# Altium schematic sheet 03-10715_Rev_0.1_Sheet_2.SchDoc (continued)

## Library data of the parts
C16 C17 C20 C22 C25 C32 = CAP0603: Capacitor; Manufacturer 1: AVX; Manufacturer Part Number 1: 06033A471JAT2A; Description_: CAP CER 470pF 25V 5% NP0 SMD 0603; Populated: Yes; Temperature Coeffcient: NP0; Tolerance: 5%; Type: Ceramic; Voltage: 25V; Category: Capacitor; Component Kind: Standard; ComponentLink1Description: Datasheet; ComponentLink1URL: https://chn-vm-apps.microchip.com/mchprepo/parts/Datasheets/smccp.pdf; Database Table Name: Capacitor; Library Name: MCHP-CDB.DbLib; MCHP-ID: CAP0603; Operating Temperature: -55C to +125C; Package: 0603; Quantity Override: 1; Revision: 1; Status: Production; Supplier 1: Digi-Key; Supplier Part Number 1: 478-6201-1-ND
C18 C19 C26 C28 = CAP0594: Capacitor; Manufacturer 1: AVX; Manufacturer Part Number 1: 06035A102JAT2A; Description_: CAP CER 1000pF 50V 5% C0G SMD 0603; Populated: Yes; Temperature Coeffcient: C0G; Tolerance: 5%; Type: Ceramic; Voltage: 50V; Category: Capacitor; Component Kind: Standard; ComponentLink1Description: Datasheet; ComponentLink1URL: https://chn-vm-apps.microchip.com/mchprepo/parts/Datasheets/smccp.pdf; Database Table Name: Capacitor; Library Name: MCHP-CDB.DbLib; Manufacturer 2: TDK; Manufacturer Part Number 2: C1608C0G1H102J; MCHP-ID: CAP0594; Operating Temperature: -55C to +125C; Package: 0603; Quantity Override: 1; Revision: 1; Status: Production; Supplier 1: Digi-Key; Supplier 2: Digi-Key; Supplier Part Number 1: 478-1187-2-ND; Supplier Part Number 2: 445-1293-2-ND
C21 = CAP1130: Capacitor; Manufacturer 1: TDK; Manufacturer Part Number 1: C1608X7R1H333K; Description_: CAP CER 0.033uF 50V 10% X7R SMD 0603; Dev Tools Status: Production; Owner: Bogdan P (M91398); Populated: YES; Temperature Coeffcient: X7R; Tolerance: 10%; Type: Ceramic; Voltage: 50V; Category: Capacitor; Component Kind: Standard; ComponentLink1Description: Datasheet; ComponentLink1URL: https://chn-vm-apps.microchip.com/mchprepo/parts/Datasheets/general_B11.pdf; Database Table Name: Capacitor; Library Name: MCHP-CDB.DbLib; MCHP-ID: CAP1130; Operating Temperature: -55C to +125C; Package: 0603; Quantity Override: 1; Revision: 0; Status: MCL Design; Supplier 1: Digi-Key; Supplier Part Number 1: 445-5093-1-ND; Symbol: Capacitor NP
C23 C27 = CAP0011: Capacitor; Manufacturer 1: NIC Components; Manufacturer Part Number 1: NMC0603X7R104K16TRPF; Description_: CAP CER 0.1uF 16V 10% X7R SMD 0603; Populated: Yes; Temperature Coeffcient: X7R; Tolerance: 10%; Type: Ceramic; Category: Capacitor; Component Kind: Standard; ComponentLink1Description: Datasheet; ComponentLink1URL: https://chn-vm-apps.microchip.com/mchprepo/parts/Datasheets/nmc2.pdf; Database Table Name: Capacitor; Library Name: MCHP-CDB.DbLib; Manufacturer 2: Panasonic; Manufacturer 3: Taiyo Yuden; Manufacturer 4: Yageo; Manufacturer 5: Murata; Manufacturer 6: AVX; Manufacturer Part Number 2: ECJ-1VB1C104K; Manufacturer Part Number 3: EMK107B7104KA-T; Manufacturer Part Number 4: CC0603KRX7R7BB104; Manufacturer Part Number 5: GRM188R71C104KA01D; Manufacturer Part Number 6: 0603YC104KAT2A; MCHP-ID: CAP0011; Operating Temperature: -55C to +125C; Quantity Override: 1; Revision: 3; Status: Production; Supplier 1: NIC Components; Supplier 2: Digi-Key; Supplier 3: Digi-Key; Supplier 4: Newark; Supplier 5: Digi-Key; Supplier 6: Digi-Key; Supplier 7: Digi-Key; Supplier Part Number 1: NMC0603X7R104K16TRPF; Supplier Part Number 2: PCC1762CT-ND; Supplier Part Number 3: 587-1240-2-ND; Supplier Part Number 4: 86H5248; Supplier Part Number 5: 311-1088-1-ND; Supplier Part Number 6: 490-1532-1-ND; Supplier Part Number 7: 478-1239-1-ND
  C27: Package: 0603
C24 C30 C34 = CAP0011: Capacitor; Manufacturer 1: NIC Components; Manufacturer Part Number 1: NMC0603X7R104K16TRPF; Description_: CAP CER 0.1uF 16V 10% X7R SMD 0603; Temperature Coeffcient: X7R; Tolerance: 10%; Type: Ceramic; Value: 0.1uF; Voltage: 16V; Category: Capacitor; Component Kind: Standard; ComponentLink1Description: Datasheet; ComponentLink1URL: https://chn-vm-apps.microchip.com/mchprepo/parts/Datasheets/nmc2.pdf; Database Table Name: Capacitor; Library Name: MCHP-CDB.DbLib; Manufacturer 2: Panasonic; Manufacturer 3: Taiyo Yuden; Manufacturer 4: Yageo; Manufacturer 5: AVX; Manufacturer Part Number 2: ECJ-1VB1C104K; Manufacturer Part Number 3: EMK107B7104KA-T; Manufacturer Part Number 4: CC0603KRX7R7BB104; Manufacturer Part Number 5: 0603YC104KAT2A; MCHP-ID: CAP0011; Operating Temperature: -55C to +125C; Quantity Override: 1; Revision: 3; Status: Production; Supplier 1: NIC Components; Supplier 2: Digi-Key; Supplier 3: Digi-Key; Supplier 4: Newark; Supplier 5: Digi-Key; Supplier 6: Digi-Key; Supplier Part Number 1: NMC0603X7R104K16TRPF; Supplier Part Number 2: PCC1762CT-ND; Supplier Part Number 3: 587-1240-2-ND; Supplier Part Number 4: 86H5248; Supplier Part Number 5: 311-1088-1-ND; Supplier Part Number 6: 478-1239-1-ND
  C34: Package: 0603
C29 = CAP0594: Capacitor; Manufacturer 1: AVX; Manufacturer Part Number 1: 06035A102JAT2A; Description_: CAP CER 1000pF 50V 5% C0G SMD 0603; Temperature Coeffcient: C0G; Tolerance: 5%; Type: Ceramic; Value: 1000pF; Voltage: 50V; Category: Capacitor; Component Kind: Standard; ComponentLink1Description: Datasheet; ComponentLink1URL: https://chn-vm-apps.microchip.com/mchprepo/parts/Datasheets/smccp.pdf; Database Table Name: Capacitor; Library Name: MCHP-CDB.DbLib; Manufacturer 2: TDK; Manufacturer Part Number 2: C1608C0G1H102J; MCHP-ID: CAP0594; Operating Temperature: -55C to +125C; Package: 0603; Quantity Override: 1; Revision: 1; Status: Production; Supplier 1: Digi-Key; Supplier 2: Digi-Key; Supplier Part Number 1: 478-1187-2-ND; Supplier Part Number 2: 445-1293-2-ND
C31 C33 = CAP0011: Capacitor; Manufacturer 1: NIC Components; Manufacturer Part Number 1: NMC0603X7R104K16TRPF; Description_: CAP CER 0.1uF 16V 10% X7R SMD 0603; Populated: Yes; Temperature Coeffcient: X7R; Tolerance: 10%; Type: Ceramic; Voltage: 16V; Category: Capacitor; Component Kind: Standard; ComponentLink1Description: Datasheet; ComponentLink1URL: https://chn-vm-apps.microchip.com/mchprepo/parts/Datasheets/nmc2.pdf; Database Table Name: Capacitor; Library Name: MCHP-CDB.DbLib; Manufacturer 2: Panasonic; Manufacturer 3: Taiyo Yuden; Manufacturer 4: Yageo; Manufacturer 5: Murata; Manufacturer 6: AVX; Manufacturer Part Number 2: ECJ-1VB1C104K; Manufacturer Part Number 3: EMK107B7104KA-T; Manufacturer Part Number 4: CC0603KRX7R7BB104; Manufacturer Part Number 5: GRM188R71C104KA01D; Manufacturer Part Number 6: 0603YC104KAT2A; MCHP-ID: CAP0011; Operating Temperature: -55C to +125C; Package: 0603; Quantity Override: 1; Revision: 3; Status: Production; Supplier 1: NIC Components; Supplier 2: Digi-Key; Supplier 3: Digi-Key; Supplier 4: Newark; Supplier 5: Digi-Key; Supplier 6: Digi-Key; Supplier 7: Digi-Key; Supplier Part Number 1: NMC0603X7R104K16TRPF; Supplier Part Number 2: PCC1762CT-ND; Supplier Part Number 3: 587-1240-2-ND; Supplier Part Number 4: 86H5248; Supplier Part Number 5: 311-1088-1-ND; Supplier Part Number 6: 490-1532-1-ND; Supplier Part Number 7: 478-1239-1-ND
C35-C38 = CAP0035: Capacitor; Manufacturer 1: Panasonic; Manufacturer Part Number 1: ECU-V1H101JCV; Description_: CAP CER 100pF 50V 5% NP0 SMD 0603; Populated: Yes; Temperature Coeffcient: NP0; Tolerance: 5%; Type: Ceramic; Voltage: 50V; Category: Capacitor; Component Kind: Standard; ComponentLink1Description: Datasheet; ComponentLink1URL: https://chn-vm-apps.microchip.com/mchprepo/parts/Datasheets/ECJ__General_.pdf; Database Table Name: Capacitor; Library Name: MCHP-CDB.DbLib; Manufacturer 2: Cal-Chip; Manufacturer 3: NIC Components; Manufacturer 4: Panasonic; Manufacturer 5: AVX; Manufacturer 6: TDK; Manufacturer Part Number 2: GMC10CG101J50NTLF; Manufacturer Part Number 3: NMC0603NPO101J50TRPF; Manufacturer Part Number 4: ECJ-1VC1H101J; Manufacturer Part Number 5: 06035A101JAT2A; Manufacturer Part Number 6: C1608C0G1H101J/10; MCHP-ID: CAP0035; Operating Temperature: -55C to +125C; Package: 0603; Quantity Override: 1; Revision: 2; Status: Production; Supplier 1: Digi-Key; Supplier 2: Cal-Chip; Supplier 3: NIC Components; Supplier 4: Digi-Key; Supplier 5: Digi-Key; Supplier 6: Digi-Key; Supplier Part Number 1: PCC101ACVTR-ND; Supplier Part Number 2: GMC10CG101J50NTLF; Supplier Part Number 3: NMC0603NPO101J50TRPF; Supplier Part Number 4: PCC101ACVCT-ND; Supplier Part Number 5: 478-1175-1-ND; Supplier Part Number 6: C1608C0G1H101J/10-ND
C75 = CAP0035: Capacitor; Manufacturer 1: Cal-Chip; Manufacturer Part Number 1: GMC10CG101J50NTLF; Description_: CAP CER 100pF 50V 5% NP0 SMD 0603; Dev Tools Status: Production; Populated: DNP; Temperature Coeffcient: NP0; Tolerance: 5%; Type: Ceramic; Voltage: 50V; Category: Capacitor; Component Kind: Standard; ComponentLink1Description: SVN Datasheet; ComponentLink1URL: https://chn-vm-apps.microchip.com/mchprepo/parts/Datasheets/ECJ__General_.pdf; ComponentLink2Description: Local Datasheet; ComponentLink3Description: Open Symbol; ComponentLink4Description: Open Footprint; ComponentLink5Description: Open Footprint 2; ComponentLink6Description: Open Footprint 3; ComponentLink7Description: Open Footprint 4; Database Table Name: Capacitor; Library Name: MCHP-CDB-Offline.DbLib; Manufacturer 2: AVX; Manufacturer 3: Panasonic; Manufacturer 4: Panasonic; Manufacturer 5: TDK; Manufacturer 6: NIC Components; Manufacturer Part Number 2: 06035A101JAT2A; Manufacturer Part Number 3: ECU-V1H101JCV; Manufacturer Part Number 4: ECJ-1VC1H101J; Manufacturer Part Number 5: C1608C0G1H101J/10; Manufacturer Part Number 6: NMC0603NPO101J50TRPF; MCHP-ID: CAP0035; Operating Temperature: -55C to +125C; Package: 0603; Quantity Override: 1; Revision: 2; Status: MCL Design; Supplier 1: Cal-Chip; Supplier 2: Digi-Key; Supplier 3: Digi-Key; Supplier 4: Digi-Key; Supplier 5: Digi-Key; Supplier 6: NIC Components; Supplier Part Number 1: GMC10CG101J50NTLF; Supplier Part Number 2: 478-1175-1-ND; Supplier Part Number 3: PCC101ACVTR-ND; Supplier Part Number 4: PCC101ACVCT-ND; Supplier Part Number 5: C1608C0G1H101J/10-ND; Supplier Part Number 6: NMC0603NPO101J50TRPF; Symbol: Capacitor NP; ComponentLink2URL: C:\ALTIUM_WORK\00001_MCHP-CDB\Datasheets\ECJ__General_.pdf; ComponentLink3URL: C:\ALTIUM_WORK\00001_MCHP-CDB\Library\LibraryRefs\Capacitor NP.SchLib; ComponentLink4URL: C:\ALTIUM_WORK\00001_MCHP-CDB\Library\Footprints\CAP_C_0603.PcbLib
C78-C80 = CAP1635: Capacitor; Manufacturer 1: TDK Corporation; Manufacturer Part Number 1: C1608C0G1H121J080AA; Description_: CAP CER 120pF 50V 5% C0G SMD 0603; Dev Tools Status: Design; Owner: Razvan Cristescu; Populated: YES; Temperature Coeffcient: C0G; Tolerance: 5%; Type: Ceramic; Voltage: 50V; Category: Capacitor; Component Kind: Standard; ComponentLink1Description: SVN Datasheet; ComponentLink1URL: https://chn-vm-apps.microchip.com/mchprepo/parts/Datasheets/1913358.pdf; ComponentLink2Description: Local Datasheet; ComponentLink3Description: Open Symbol; ComponentLink4Description: Open Footprint; ComponentLink5Description: Open Footprint 2; ComponentLink6Description: Open Footprint 3; ComponentLink7Description: Open Footprint 4; Database Table Name: Capacitor; Library Name: MCHP-CDB.DbLib; MCHP-ID: CAP1635; Operating Temperature: -55C to +125C; Package: 0603; Quantity Override: 1; Revision: A; Status: MCL Design; Supplier 1: Farnell; Supplier Part Number 1: 2371946; Symbol: Capacitor NP; ComponentLink2URL: C:\ALTIUM_WORK\00001_MCHP-CDB\Datasheets\1913358.pdf; ComponentLink3URL: C:\ALTIUM_WORK\00001_MCHP-CDB\Library\LibraryRefs\Capacitor NP.SchLib; ComponentLink4URL: C:\ALTIUM_WORK\00001_MCHP-CDB\Library\Footprints\CAP_C_0603.PcbLib
D17-D19 = DIODE1074: Manufacturer 1: Vishay Semiconductor Diodes Division; Manufacturer Part Number 1: BAS40-04-E3-08; Description_: DIO SCTKYARR BAS40-04 1V 200mA 40V SOT-23-3; Dev Tools Status: Production; Forward Current: 200mA; Owner: Stephen Humberd; Populated: YES; Power: 200mW; Reverse Current: 100nA; Reverse Voltage: 40V; Type: Schottky Array; Category: Diode; Component Kind: Standard; ComponentLink1Description: SVN Datasheet; ComponentLink1URL: https://chn-vm-apps.microchip.com/mchprepo/parts/Datasheets/bas40v.pdf; ComponentLink2Description: Local Datasheet; ComponentLink3Description: Open Symbol; ComponentLink4Description: Open Footprint; ComponentLink5Description: Open Footprint 2; ComponentLink6Description: Open Footprint 3; ComponentLink7Description: Open Footprint 4; Database Table Name: Diode; Library Name: MCHP-CDB.DbLib; MCHP-ID: DIODE1074; Operating Temperature: -65C to +125C; Package: SOT-23-3; Quantity Override: 1; Revision: A; Status: MCL Design; Supplier 1: Digi-Key; Supplier Part Number 1: BAS40-04-E3-08CT-ND; Symbol: Diode Schottky DUAL AK; ComponentLink2URL: C:\ALTIUM_WORK\00001_MCHP-CDB\Datasheets\bas40v.pdf; ComponentLink3URL: C:\ALTIUM_WORK\00001_MCHP-CDB\Library\LibraryRefs\Diode Schottky DUAL AK.SchLib; ComponentLink4URL: C:\ALTIUM_WORK\00001_MCHP-CDB\Library\Footprints\SOT-23-3.PcbLib
DCBUS1 M1_IA M1_IB M1_IBUS QE_A QE_B QE_HOME QE_INDEX VA VB VC = CON1128: Test Point; Database Table Name: Connector; Description_: CON TP Via_1.2x0.7; Owner: Bogdan P (M91398); Populated: Yes; Value: 1x1; Category: Connector; ComponentLink1Description: Datasheet; Library Name: MCHP-CDB.DbLib; MCHP-ID: CON1128; Quantity Override: 1; Revision: 0; Status: Production; Type: Test Point
J3 = CON1187: Manufacturer 1: On Shore Technology Inc; Manufacturer Part Number 1: ED500/6DS; Current: 15A; Database Table Name: Connector; Description_: CON TERMINAL 5mm 1x6 Female 14-22AWG 15A TH R/A; Dev Tools Status: Production; Owner: Stephen Humberd; Voltage: 300V; Category: Connector; Component Kind: Standard; ComponentLink1Description: Datasheet; ComponentLink1URL: https://chn-vm-apps.microchip.com/mchprepo/parts/Datasheets/ed500.pdf; Library Name: MCHP-CDB.DbLib; MCHP-ID: CON1187; Quantity Override: 1; Revision: A; Status: MCL Design; Supplier 1: Digi-Key; Supplier Part Number 1: ED2223-ND; Symbol: Header 1x6; Type: Terminal Block
R46 R47 R58 R60 R67 R70 R85 R153-R157 = RES1318: Manufacturer 1: Stackpole Electronics Inc; Manufacturer Part Number 1: RMCF0603FT30K0; Circuit Type: Isolated; Description_: RES TKF 30k 1% 1/10W SMD 0603; Dev Tools Status: Production; Owner: Stephen Humberd; Populated: YES; Power: 1/10W; Temperature Coeffcient: 100ppm/C; Tolerance: 1%; Voltage: 75V; Category: Resistor; Component Kind: Standard; ComponentLink1Description: SVN Datasheet; ComponentLink1URL: https://chn-vm-apps.microchip.com/mchprepo/parts/Datasheets/AOA0000CE2.pdf; ComponentLink2Description: Local Datasheet; ComponentLink3Description: Open Symbol; ComponentLink4Description: Open Footprint; ComponentLink5Description: Open Footprint 2; ComponentLink6Description: Open Footprint 3; ComponentLink7Description: Open Footprint 4; Composition: TKF; Database Table Name: Resistor; Description: Resistor; Library Name: MCHP-CDB-Offline.DbLib; MCHP-ID: RES1318; Operating Temperature: -55C to +155C; Package: 0603; Quantity Override: 1; Revision: A; Status: MCL Design; Supplier 1: Digi-Key; Supplier Part Number 1: RMCF0603FT30K0TR-ND; Symbol: Resistor; Type: RES SMD; ComponentLink2URL: C:\ALTIUM_WORK\00001_MCHP-CDB\Datasheets\AOA0000CE2.pdf; ComponentLink3URL: C:\ALTIUM_WORK\00001_MCHP-CDB\Library\LibraryRefs\Resistor.SchLib; ComponentLink4URL: C:\ALTIUM_WORK\00001_MCHP-CDB\Library\Footprints\RES_C_0603.PcbLib
R48 R71 R82 = RSMT0138: Resistor; Manufacturer 1: Panasonic; Manufacturer Part Number 1: ERJ-3EKF1001V; Description_: RES TKF 1k 1% 1/10W SMD 0603; Power: 1/10W; Temperature Coeffcient: 100ppm/C; Tolerance: 1%; Value: 1k; Voltage: 75V; Category: Resistor; Component Kind: Standard; ComponentLink1Description: Datasheet; ComponentLink1URL: https://chn-vm-apps.microchip.com/mchprepo/parts/Datasheets/AOA0000CE2.pdf; Composition: TKF; Database Table Name: Resistor; Library Name: MCHP-CDB.DbLib; Manufacturer 2: ROHM; Manufacturer 3: Vishay; Manufacturer 4: Stackpole Electronics Inc; Manufacturer 5: Yageo; Manufacturer 6: Stackpole Electronics Inc; Manufacturer 7: Stackpole Electronics Inc; Manufacturer Part Number 2: MCR03EZPFX1001; Manufacturer Part Number 3: CRCW06031K00FKEA; Manufacturer Part Number 4: RMCF1/161.0K1%TR; Manufacturer Part Number 5: RC0603FR-071KL; Manufacturer Part Number 6: RMCF0603FT1K00; Manufacturer Part Number 7: RMCF 1/16 1K 1% R; MCHP-ID: RSMT0138; Operating Temperature: -55C to +155C; Package: 0603; Quantity Override: 1; Revision: 2; Status: Production; Supplier 1: Digi-Key; Supplier 2: Digi-Key; Supplier 3: Digi-Key; Supplier 4: Newark; Supplier 5: Stackpole Electronics Inc; Supplier 6: Digi-Key; Supplier 7: Digi-Key; Supplier 8: Digi-Key; Supplier Part Number 1: P1.00KHTR-ND; Supplier Part Number 2: P1.00KHCT-ND; Supplier Part Number 3: RHM1.00KHCT-ND; Supplier Part Number 4: 52K8015; Supplier Part Number 5: RMCF1/161.0K1%TR; Supplier Part Number 6: 311-1.00KHRCT-ND; Supplier Part Number 7: RMCF1/161KFRTR-ND; Supplier Part Number 8: RMCF0603FT1K00TR-ND; Type: RES SMD
R49-R52 R54-R57 R72 R73 R80 R81 R86 = RSMT0138: Resistor; Manufacturer 1: Panasonic; Manufacturer Part Number 1: ERJ-3EKF1001V; Description_: RES TKF 1k 1% 1/10W SMD 0603; Populated: Yes; Power: 1/10W; Temperature Coeffcient: 100ppm/C; Tolerance: 1%; Voltage: 75V; Category: Resistor; Component Kind: Standard; ComponentLink1Description: Datasheet; ComponentLink1URL: https://chn-vm-apps.microchip.com/mchprepo/parts/Datasheets/AOA0000CE2.pdf; Composition: TKF; Database Table Name: Resistor; Library Name: MCHP-CDB.DbLib; Manufacturer 2: ROHM; Manufacturer 3: Vishay; Manufacturer 4: Stackpole Electronics Inc; Manufacturer 5: Yageo; Manufacturer 6: Stackpole Electronics Inc; Manufacturer 7: Stackpole Electronics Inc; Manufacturer Part Number 2: MCR03EZPFX1001; Manufacturer Part Number 3: CRCW06031K00FKEA; Manufacturer Part Number 4: RMCF1/161.0K1%TR; Manufacturer Part Number 5: RC0603FR-071KL; Manufacturer Part Number 6: RMCF0603FT1K00; Manufacturer Part Number 7: RMCF 1/16 1K 1% R; MCHP-ID: RSMT0138; Operating Temperature: -55C to +155C; Package: 0603; Quantity Override: 1; Revision: 2; Status: Production; Supplier 1: Digi-Key; Supplier 2: Digi-Key; Supplier 3: Digi-Key; Supplier 4: Newark; Supplier 5: Stackpole Electronics Inc; Supplier 6: Digi-Key; Supplier 7: Digi-Key; Supplier 8: Digi-Key; Supplier Part Number 1: P1.00KHTR-ND; Supplier Part Number 2: P1.00KHCT-ND; Supplier Part Number 3: RHM1.00KHCT-ND; Supplier Part Number 4: 52K8015; Supplier Part Number 5: RMCF1/161.0K1%TR; Supplier Part Number 6: 311-1.00KHRCT-ND; Supplier Part Number 7: RMCF1/161KFRTR-ND; Supplier Part Number 8: RMCF0603FT1K00TR-ND; Type: RES SMD
R53 R66 R78 R89 = RES1126: Manufacturer 1: Panasonic; Manufacturer Part Number 1: ERJ-3EKF1802V; Description_: RES TKF 18k 1% 1/10W SMD 0603; Owner: Bogdan P (M91398); Populated: Yes; Power: 1/10W; Temperature Coeffcient: 100ppm/C; Tolerance: 1%; Voltage: 75V; Category: Resistor; Component Kind: Standard; ComponentLink1Description: Datasheet; ComponentLink1URL: https://chn-vm-apps.microchip.com/mchprepo/parts/Datasheets/AOA0000CE2.pdf; Composition: TKF; Database Table Name: Resistor; Description: Resistor; Library Name: MCHP-CDB.DbLib; MCHP-ID: RES1126; Operating Temperature: -55C to +155C; Quantity Override: 1; Revision: 0; Status: Production; Supplier 1: Digi-Key; Supplier Part Number 1: P18.0KHCT-ND; Type: RES SMD
  R66: Package: 0603
R59 R75 = RSMT0001: Resistor; Manufacturer 1: NIC Components; Manufacturer Part Number 1: NRC06Z0TRF; Description_: RES TKF 0R 1/10W SMD 0603; Populated: Yes; Power: 1/10W; Category: Resistor; Component Kind: Standard; ComponentLink1Description: Datasheet; ComponentLink1URL: https://chn-vm-apps.microchip.com/mchprepo/parts/Datasheets/nrc.pdf; Composition: TKF; Database Table Name: Resistor; Library Name: MCHP-CDB.DbLib; Manufacturer 2: Panasonic; Manufacturer 3: Panasonic; Manufacturer 4: Stackpole Electronics Inc; Manufacturer 5: Vishay; Manufacturer 6: Stackpole Electronics Inc; Manufacturer 7: Yageo; Manufacturer 8: KOA Speer; Manufacturer 9: ROHM; Manufacturer Part Number 2: ERJ-3GSY0R00V; Manufacturer Part Number 3: ERJ-3GEY0R00V; Manufacturer Part Number 4: RMCF0603ZT0R00; Manufacturer Part Number 5: CRCW06030000Z0EA; Manufacturer Part Number 6: RMCF 1/16 0 R; Manufacturer Part Number 7: RC0603JR-070RL; Manufacturer Part Number 8: RK73Z1JTTP; Manufacturer Part Number 9: MCR03EZPJ000; MCHP-ID: RSMT0001; Operating Temperature: -55C to +155C; Quantity Override: 1; Revision: 2; Status: Production; Supplier 1: NIC Components; Supplier 2: Digi-Key; Supplier 3: Digi-Key; Supplier 4: Digi-Key; Supplier 5: Digi-Key; Supplier 6: Digi-Key; Supplier 7: Digi-Key; Supplier 8: KOA Speer; Supplier 9: Digi-Key; Supplier Part Number 1: NRC06Z0TRF; Supplier Part Number 2: P0.0GTR-ND; Supplier Part Number 3: RMCF0603ZT0R00TR-ND; Supplier Part Number 4: RMCF0603ZT0R00CT-ND; Supplier Part Number 5: 541-0.0GCT-ND; Supplier Part Number 6: RMCF1/160RTR-ND; Supplier Part Number 7: 311-0.0GRCT-ND; Supplier Part Number 8: RK73Z1JTTP; Supplier Part Number 9: RHM0.0GCT-ND; Type: RES SMD
  R59: Package: 0603
R61 R68 R83 R91 = RES1110: Manufacturer 1: Panasonic; Manufacturer Part Number 1: ERJ-3EKF1801V; Description_: RES TKF 1.8k 1% 1/10W SMD 0603; Owner: Bogdan P (M91398); Populated: Yes; Power: 1/10W; Temperature Coeffcient: 100ppm/C; Tolerance: 1%; Voltage: 75V; Category: Resistor; Component Kind: Standard; ComponentLink1Description: Datasheet; ComponentLink1URL: https://chn-vm-apps.microchip.com/mchprepo/parts/Datasheets/AOA0000CE2.pdf; Composition: TKF; Database Table Name: Resistor; Description: Resistor; Library Name: MCHP-CDB.DbLib; MCHP-ID: RES1110; Operating Temperature: -55C to +155C; Quantity Override: 1; Revision: 0; Status: Production; Supplier 1: Digi-Key; Supplier Part Number 1: P1.80KHCT-ND; Type: RES SMD
R62 = RSMT0138: Resistor; Manufacturer 1: Panasonic; Manufacturer Part Number 1: ERJ-3EKF1001V; Description_: RES TKF 1k 1% 1/10W SMD 0603; Power: 1/10W; Temperature Coeffcient: 100ppm/C; Tolerance: 1%; Voltage: 75V; Category: Resistor; Component Kind: Standard; ComponentLink1Description: Datasheet; ComponentLink1URL: https://chn-vm-apps.microchip.com/mchprepo/parts/Datasheets/AOA0000CE2.pdf; Composition: TKF; Database Table Name: Resistor; Library Name: MCHP-CDB.DbLib; Manufacturer 2: ROHM; Manufacturer 3: Vishay; Manufacturer 4: Stackpole Electronics Inc; Manufacturer 5: Yageo; Manufacturer 6: Stackpole Electronics Inc; Manufacturer 7: Stackpole Electronics Inc; Manufacturer Part Number 2: MCR03EZPFX1001; Manufacturer Part Number 3: CRCW06031K00FKEA; Manufacturer Part Number 4: RMCF1/161.0K1%TR; Manufacturer Part Number 5: RC0603FR-071KL; Manufacturer Part Number 6: RMCF0603FT1K00; Manufacturer Part Number 7: RMCF 1/16 1K 1% R; MCHP-ID: RSMT0138; Operating Temperature: -55C to +155C; Package: 0603; Quantity Override: 1; Revision: 2; Status: Production; Supplier 1: Digi-Key; Supplier 2: Digi-Key; Supplier 3: Digi-Key; Supplier 4: Newark; Supplier 5: Stackpole Electronics Inc; Supplier 6: Digi-Key; Supplier 7: Digi-Key; Supplier 8: Digi-Key; Supplier Part Number 1: P1.00KHTR-ND; Supplier Part Number 2: P1.00KHCT-ND; Supplier Part Number 3: RHM1.00KHCT-ND; Supplier Part Number 4: 52K8015; Supplier Part Number 5: RMCF1/161.0K1%TR; Supplier Part Number 6: 311-1.00KHRCT-ND; Supplier Part Number 7: RMCF1/161KFRTR-ND; Supplier Part Number 8: RMCF0603FT1K00TR-ND; Type: RES SMD
R63 = RSMT0001: Resistor; Manufacturer 1: NIC Components; Manufacturer Part Number 1: NRC06Z0TRF; Description_: RES TKF 0R 1/10W SMD 0603; Power: 1/10W; Value: 0R; Category: Resistor; Component Kind: Standard; ComponentLink1Description: Datasheet; ComponentLink1URL: https://chn-vm-apps.microchip.com/mchprepo/parts/Datasheets/nrc.pdf; Composition: TKF; Database Table Name: Resistor; Library Name: MCHP-CDB.DbLib; Manufacturer 2: Panasonic; Manufacturer 3: Panasonic; Manufacturer 4: Stackpole Electronics Inc; Manufacturer 5: Vishay; Manufacturer 6: Stackpole Electronics Inc; Manufacturer 7: Yageo; Manufacturer 8: KOA Speer; Manufacturer 9: ROHM; Manufacturer Part Number 2: ERJ-3GSY0R00V; Manufacturer Part Number 3: ERJ-3GEY0R00V; Manufacturer Part Number 4: RMCF0603ZT0R00; Manufacturer Part Number 5: CRCW06030000Z0EA; Manufacturer Part Number 6: RMCF 1/16 0 R; Manufacturer Part Number 7: RC0603JR-070RL; Manufacturer Part Number 8: RK73Z1JTTP; Manufacturer Part Number 9: MCR03EZPJ000; MCHP-ID: RSMT0001; Operating Temperature: -55C to +155C; Package: 0603; Quantity Override: 1; Revision: 2; Status: Production; Supplier 1: NIC Components; Supplier 2: Digi-Key; Supplier 3: Digi-Key; Supplier 4: Digi-Key; Supplier 5: Digi-Key; Supplier 6: Digi-Key; Supplier 7: Digi-Key; Supplier 8: KOA Speer; Supplier 9: Digi-Key; Supplier Part Number 1: NRC06Z0TRF; Supplier Part Number 2: P0.0GTR-ND; Supplier Part Number 3: RMCF0603ZT0R00TR-ND; Supplier Part Number 4: RMCF0603ZT0R00CT-ND; Supplier Part Number 5: 541-0.0GCT-ND; Supplier Part Number 6: RMCF1/160RTR-ND; Supplier Part Number 7: 311-0.0GRCT-ND; Supplier Part Number 8: RK73Z1JTTP; Supplier Part Number 9: RHM0.0GCT-ND; Type: RES SMD
R65 R79 R90 = RES1064: Manufacturer 1: Stackpole Electronics Inc; Manufacturer Part Number 1: RMCF0603FT301R; Circuit Type: Isolated; Description_: RES TKF 301R 1% 1/10W SMD 0603; Owner: Stephen Humberd; Populated: YES; Power: 1/10W; Temperature Coeffcient: ±100ppm/°C; Tolerance: ±1%; Category: Resistor; Component Kind: Standard; ComponentLink1Description: Datasheet; ComponentLink1URL: https://chn-vm-apps.microchip.com/mchprepo/parts/Datasheets/SEI-RMCF_RMCP.pdf; Composition: TKF; Database Table Name: Resistor; Description: Resistor; Library Name: MCHP-CDB.DbLib; MCHP-ID: RES1064; Package: 0603; Quantity Override: 1; Revision: A; Status: Design; Supplier 1: Digi-Key; Supplier Part Number 1: RMCF0603FT301RCT-ND; Type: RES SMD
R69 = RSMT0138: Manufacturer 1: Panasonic; Manufacturer Part Number 1: ERJ-3EKF1001V; Description_: RES TKF 1k 1% 1/10W SMD 0603; Populated: Yes; Power: 1/10W; Temperature Coeffcient: 100ppm/C; Tolerance: 1%; Voltage: 75V; Category: Resistor; Component Kind: Standard; ComponentLink1Description: Datasheet; ComponentLink1URL: https://chn-vm-apps.microchip.com/mchprepo/parts/Datasheets/AOA0000CE2.pdf; Composition: TKF; Database Table Name: Resistor; Description: Resistor; Library Name: MCHP-CDB.DbLib; Manufacturer 2: ROHM; Manufacturer 3: Vishay; Manufacturer 4: Stackpole Electronics Inc; Manufacturer 5: Yageo; Manufacturer 6: Stackpole Electronics Inc; Manufacturer 7: Stackpole Electronics Inc; Manufacturer Part Number 2: MCR03EZPFX1001; Manufacturer Part Number 3: CRCW06031K00FKEA; Manufacturer Part Number 4: RMCF1/161.0K1%TR; Manufacturer Part Number 5: RC0603FR-071KL; Manufacturer Part Number 6: RMCF0603FT1K00; Manufacturer Part Number 7: RMCF 1/16 1K 1% R; MCHP-ID: RSMT0138; Operating Temperature: -55C to +155C; Package: 0603; Quantity Override: 1; Revision: 2; Status: Production; Supplier 1: Digi-Key; Supplier 2: Digi-Key; Supplier 3: Digi-Key; Supplier 4: Newark; Supplier 5: Stackpole Electronics Inc; Supplier 6: Digi-Key; Supplier 7: Digi-Key; Supplier 8: Digi-Key; Supplier Part Number 1: P1.00KHTR-ND; Supplier Part Number 2: P1.00KHCT-ND; Supplier Part Number 3: RHM1.00KHCT-ND; Supplier Part Number 4: 52K8015; Supplier Part Number 5: RMCF1/161.0K1%TR; Supplier Part Number 6: 311-1.00KHRCT-ND; Supplier Part Number 7: RMCF1/161KFRTR-ND; Supplier Part Number 8: RMCF0603FT1K00TR-ND; Type: RES SMD
R76 = RSMT0139: Manufacturer 1: NIC Components; Manufacturer Part Number 1: NRC06F1002TRF; Description_: RES TKF 10k 1% 1/10W SMD 0603; Populated: Yes; Power: 1/10W; Temperature Coeffcient: 100ppm/C; Tolerance: 1%; Category: Resistor; Component Kind: Standard; ComponentLink1Description: Datasheet; ComponentLink1URL: https://chn-vm-apps.microchip.com/mchprepo/parts/Datasheets/nrc.pdf; Composition: TKF; Database Table Name: Resistor; Description: Resistor; Library Name: MCHP-CDB.DbLib; Manufacturer 2: Panasonic; Manufacturer 3: Stackpole Electronics Inc; Manufacturer 4: Xicon; Manufacturer 5: Stackpole Electronics Inc; Manufacturer 6: Yageo; Manufacturer Part Number 2: ERJ-3EKF1002V; Manufacturer Part Number 3: RMCF0603FT10K0; Manufacturer Part Number 4: 302-10K-RC; Manufacturer Part Number 5: RMCF 1/16 10K 1% R; Manufacturer Part Number 6: RC0603FR-0710KL; MCHP-ID: RSMT0139; Operating Temperature: -55C to +155C; Package: 0603; Quantity Override: 1; Revision: 2; Status: Production; Supplier 1: NIC Components; Supplier 2: Digi-Key; Supplier 3: Digi-Key; Supplier 4: Mouser; Supplier 5: Digi-Key; Supplier 6: Digi-Key; Supplier Part Number 1: NRC06F1002TRF; Supplier Part Number 2: P10.0KHCT-ND; Supplier Part Number 3: RMCF1/1610KFRTR-ND; Supplier Part Number 4: 302-10k-RC; Supplier Part Number 5: RMCF0603FT10K0TR-ND; Supplier Part Number 6: 311-10.0KHRCT-ND; Type: RES SMD
R77 = RES1137: Manufacturer 1: Panasonic; Manufacturer Part Number 1: ERJ-3EKF20R0V; Description_: RES TKF 20R 1% 1/10W SMD 0603; Owner: Bogdan P (M91398); Populated: Yes; Power: 1/10W; Temperature Coeffcient: 100ppm/C; Tolerance: 1%; Voltage: 75V; Category: Resistor; Component Kind: Standard; ComponentLink1Description: Datasheet; ComponentLink1URL: https://chn-vm-apps.microchip.com/mchprepo/parts/Datasheets/AOA0000CE2.pdf; Composition: TKF; Database Table Name: Resistor; Description: Resistor; Library Name: MCHP-CDB.DbLib; MCHP-ID: RES1137; Operating Temperature: -55C to +155C; Package: 0603; Quantity Override: 1; Revision: 0; Status: Production; Supplier 1: Digi-Key; Supplier Part Number 1: P20.0HCT-ND; Type: RES SMD
R87 = RSMT0137: Manufacturer 1: Panasonic; Manufacturer Part Number 1: ERJ-3GSYJ271V; Description_: RES TKF 270R 5% 1/10W SMD 0603; Dev Tools Status: Production; Populated: Yes; Power: 1/10W; Temperature Coeffcient: 200ppm/C; Tolerance: 5%; Voltage: 75V; Category: Resistor; Component Kind: Standard; ComponentLink1Description: SVN Datasheet; ComponentLink1URL: https://chn-vm-apps.microchip.com/mchprepo/parts/Datasheets/AOA0000CE1.pdf; ComponentLink2Description: Local Datasheet; ComponentLink3Description: Open Symbol; ComponentLink4Description: Open Footprint; ComponentLink5Description: Open Footprint 2; ComponentLink6Description: Open Footprint 3; ComponentLink7Description: Open Footprint 4; Composition: TKF; Database Table Name: Resistor; Description: Resistor; Library Name: MCHP-CDB.DbLib; Manufacturer 2: KOA Speer; Manufacturer 3: Stackpole Electronics Inc; Manufacturer Part Number 2: RK73B1JTTD271J; Manufacturer Part Number 3: RMCF 1/16 270 5% R; MCHP-ID: RSMT0137; Operating Temperature: -55C to +155C; Package: 0603; Quantity Override: 1; Revision: 1; Status: MCL Design; Supplier 1: Digi-Key; Supplier 2: KOA Speer; Supplier 3: Stackpole Electronics Inc; Supplier Part Number 1: P270GTR-ND; Supplier Part Number 2: RK73B1JTTD271J; Supplier Part Number 3: RMCF 1/16 270 5% R; Symbol: Resistor; Type: RES SMD; ComponentLink2URL: C:\ALTIUM_WORK\00001_MCHP-CDB\Datasheets\AOA0000CE1.pdf; ComponentLink3URL: C:\ALTIUM_WORK\00001_MCHP-CDB\Library\LibraryRefs\Resistor.SchLib; ComponentLink4URL: C:\ALTIUM_WORK\00001_MCHP-CDB\Library\Footprints\RES_C_0603.PcbLib
R92-R95 = RSMT0628: Manufacturer 1: Panasonic; Manufacturer Part Number 1: ERJ-3EKF4701V; Description_: RES TKF 4.7k 1% 1/10W SMD 0603; Populated: Yes; Power: 1/10W; Temperature Coeffcient: 100ppm/C; Tolerance: 1%; Voltage: 75V; Category: Resistor; Component Kind: Standard; ComponentLink1Description: Datasheet; ComponentLink1URL: https://chn-vm-apps.microchip.com/mchprepo/parts/Datasheets/AOA0000CE2.pdf; Composition: TKF; Database Table Name: Resistor; Description: Resistor; Library Name: MCHP-CDB.DbLib; MCHP-ID: RSMT0628; Operating Temperature: -55C to +155C; Package: 0603; Quantity Override: 1; Revision: 1; Status: Production; Supplier 1: Digi-Key; Supplier Part Number 1: P4.70KHCT-ND; Type: RES SMD
R96-R99 = RSMT0001: Manufacturer 1: NIC Components; Manufacturer Part Number 1: NRC06Z0TRF; Description_: RES TKF 0R 1/10W SMD 0603; Populated: Yes; Power: 1/10W; Category: Resistor; Component Kind: Standard; ComponentLink1Description: Datasheet; ComponentLink1URL: https://chn-vm-apps.microchip.com/mchprepo/parts/Datasheets/nrc.pdf; Composition: TKF; Database Table Name: Resistor; Description: Resistor; Library Name: MCHP-CDB.DbLib; Manufacturer 2: Panasonic; Manufacturer 3: Panasonic; Manufacturer 4: Stackpole Electronics Inc; Manufacturer 5: Vishay; Manufacturer 6: Stackpole Electronics Inc; Manufacturer 7: Yageo; Manufacturer 8: KOA Speer; Manufacturer 9: ROHM; Manufacturer Part Number 2: ERJ-3GSY0R00V; Manufacturer Part Number 3: ERJ-3GEY0R00V; Manufacturer Part Number 4: RMCF0603ZT0R00; Manufacturer Part Number 5: CRCW06030000Z0EA; Manufacturer Part Number 6: RMCF 1/16 0 R; Manufacturer Part Number 7: RC0603JR-070RL; Manufacturer Part Number 8: RK73Z1JTTP; Manufacturer Part Number 9: MCR03EZPJ000; MCHP-ID: RSMT0001; Operating Temperature: -55C to +155C; Package: 0603; Quantity Override: 1; Revision: 2; Status: Production; Supplier 1: NIC Components; Supplier 2: Digi-Key; Supplier 3: Digi-Key; Supplier 4: Digi-Key; Supplier 5: Digi-Key; Supplier 6: Digi-Key; Supplier 7: Digi-Key; Supplier 8: KOA Speer; Supplier 9: Digi-Key; Supplier Part Number 1: NRC06Z0TRF; Supplier Part Number 2: P0.0GTR-ND; Supplier Part Number 3: RMCF0603ZT0R00TR-ND; Supplier Part Number 4: RMCF0603ZT0R00CT-ND; Supplier Part Number 5: 541-0.0GCT-ND; Supplier Part Number 6: RMCF1/160RTR-ND; Supplier Part Number 7: 311-0.0GRCT-ND; Supplier Part Number 8: RK73Z1JTTP; Supplier Part Number 9: RHM0.0GCT-ND; Type: RES SMD
R100-R103 = RES1216: Manufacturer 1: Vishay; Manufacturer Part Number 1: CRCW060391K0FKEA; Description_: RES TKF 91k 1% 1/10W SMD 0603; Owner: Mihai T(M91442); Power: 1/10W; Temperature Coeffcient: 100ppm/C; Tolerance: 1%; Value: 91k; Voltage: 75V; Category: Resistor; Component Kind: Standard; ComponentLink1Description: Datasheet; ComponentLink1URL: https://chn-vm-apps.microchip.com/mchprepo/parts/Datasheets/dcrcwe3.pdf; Composition: TKF; Database Table Name: Resistor; Description: Resistor; Library Name: MCHP-CDB.DbLib; MCHP-ID: RES1216; Operating Temperature: -55C to +155C; Package: 0603; Quantity Override: 1; Revision: 0; Status: Production; Supplier 1: Digi-Key; Supplier 2: Farnell; Supplier Part Number 1: 541-91.0KHCT-ND; Supplier Part Number 2: 1469845; Type: RES SMD
R142 = RES1269: Manufacturer 1: Panasonic; Manufacturer Part Number 1: ERJ-3EKF2491V; Description_: RES TKF 2.49k 1% 1/10W SMD 0603; Dev Tools Status: Production; Owner: Tad Ahlvers; Populated: YES; Power: 1/10W; Temperature Coeffcient: 100ppm/C; Tolerance: 1%; Voltage: 75V; Category: Resistor; Component Kind: Standard; ComponentLink1Description: SVN Datasheet; ComponentLink1URL: https://chn-vm-apps.microchip.com/mchprepo/parts/Datasheets/AOA0000CE2.pdf; ComponentLink2Description: Local Datasheet; ComponentLink3Description: Open Symbol; ComponentLink4Description: Open Footprint; ComponentLink5Description: Open Footprint 2; ComponentLink6Description: Open Footprint 3; ComponentLink7Description: Open Footprint 4; Composition: TKF; Database Table Name: Resistor; Description: Resistor; Library Name: MCHP-CDB-Offline.DbLib; MCHP-ID: RES1269; Operating Temperature: -55C to +155C; Package: 0603; Quantity Override: 1; Revision: 0; Status: MCL Design; Supplier 1: Digi-Key; Supplier Part Number 1: P2.49KHCT-ND; Symbol: Resistor; Type: RES SMD; ComponentLink2URL: C:\ALTIUM_WORK\00001_MCHP-CDB\Datasheets\AOA0000CE2.pdf; ComponentLink3URL: C:\ALTIUM_WORK\00001_MCHP-CDB\Library\LibraryRefs\Resistor.SchLib; ComponentLink4URL: C:\ALTIUM_WORK\00001_MCHP-CDB\Library\Footprints\RES_C_0603.PcbLib
R143 = RSMT0465: Manufacturer 1: Panasonic; Manufacturer Part Number 1: ERJ-3EKF7501V; Description_: RES TKF 7.5k 1% 1/10W SMD 0603; Dev Tools Status: Production; Populated: Yes; Power: 1/10W; Temperature Coeffcient: 100ppm/C; Tolerance: 1%; Voltage: 75V; Category: Resistor; Component Kind: Standard; ComponentLink1Description: SVN Datasheet; ComponentLink1URL: https://chn-vm-apps.microchip.com/mchprepo/parts/Datasheets/AOA0000CE2.pdf; ComponentLink2Description: Local Datasheet; ComponentLink3Description: Open Symbol; ComponentLink4Description: Open Footprint; ComponentLink5Description: Open Footprint 2; ComponentLink6Description: Open Footprint 3; ComponentLink7Description: Open Footprint 4; Composition: TKF; Database Table Name: Resistor; Description: Resistor; Library Name: MCHP-CDB-Offline.DbLib; Manufacturer 2: ROHM; Manufacturer 3: Vishay; Manufacturer 4: Yageo; Manufacturer Part Number 2: MCR03EZPFX7501; Manufacturer Part Number 3: CRCW06037K50FKEA; Manufacturer Part Number 4: RC0603FR-077K5L; MCHP-ID: RSMT0465; Operating Temperature: -55C to +155C; Package: 0603; Quantity Override: 1; Revision: 1; Status: MCL Design; Supplier 1: Digi-Key; Supplier 2: Digi-Key; Supplier 3: Digi-Key; Supplier 4: Digi-Key; Supplier Part Number 1: P7.50KHCT-ND; Supplier Part Number 2: RHM7.50KHCT-ND; Supplier Part Number 3: 541-7.50KHCT-ND; Supplier Part Number 4: 311-7.50KHRCT-ND; Symbol: Resistor; Type: RES SMD; ComponentLink2URL: C:\ALTIUM_WORK\00001_MCHP-CDB\Datasheets\AOA0000CE2.pdf; ComponentLink3URL: C:\ALTIUM_WORK\00001_MCHP-CDB\Library\LibraryRefs\Resistor.SchLib; ComponentLink4URL: C:\ALTIUM_WORK\00001_MCHP-CDB\Library\Footprints\RES_C_0603.PcbLib
TP3 = CON1086: Test Point; Manufacturer 1: Keystone; Manufacturer Part Number 1: 5012; Database Table Name: Connector; Description_: CON TP White Male TH VERT; Owner: Stephen Humberd; Category: Connector; Component Kind: Standard; ComponentLink1Description: Datasheet; ComponentLink1URL: https://chn-vm-apps.microchip.com/mchprepo/parts/Datasheets/5012.pdf; Library Name: MCHP-CDB.DbLib; MCHP-ID: CON1086; Quantity Override: 1; Revision: A; Status: Design; Supplier 1: Digi-Key; Supplier Part Number 1: 5012K-ND; Type: Test Point; Value: VREF
U2 = MIC0507: OPAMP; Manufacturer 1: Microchip; Manufacturer Part Number 1: MCP6021T-E/OT; Amplifiers: 1; Comments: Mid-supply Internal VREF; Database Table Name: MCHP-Analog; Description_: MCHP ANA OpAmp MCP6021 SOT-23-5; ForceMinOrderQtyMult: True; GBWP_KHz: 10000; IqMax: 1350; LeadContent: Pb-FREE; LeadCount: 5; MAPS Description: 2.7-5.5 10MHz High-Speed Single, OP Amp using 120K Analog EPROM process; MPC: A7CD1YC7XA00; PackingMedia: T/R; Populated: Yes; Stage: REL; tblDeviceFamilyID: 267; TempRange: -40C to +125C; VDDmax: 5.5; VDDmin: 2.5; VosMax: 250; Category: MCHP-Analog; Component Kind: Standard; ComponentLink1Description: Datasheet; ComponentLink1URL: http://www.microchip.com/stellent/idcplg?IdcService=SS_GET_PAGE&nodeId=1335&dDocName=en010438; IntroductionDate: 2006-03-28; Library Name: MCHP-CDB.DbLib; MCHP-ID: MIC0507; MinOrderQty: 5; PackageType: SOT-23; Quantity Override: 1; RailIn: True; RailOut: True; Revision: 1; StableGainMin: 1; Status: Production; Supplier 1: Microchip; Supplier Part Number 1: MCP6021T-E/OT; Type: OpAmp
U3 = MIC0507: OPAMP; Manufacturer 1: Microchip; Manufacturer Part Number 1: MCP6021T-E/OT; Amplifiers: 1; Comments: Mid-supply Internal VREF; Database Table Name: MCHP-Analog; Description_: MCHP ANALOG OPAMP 1-Ch 10MHz MCP6021T-E/OT SOT-23-5; ForceMinOrderQtyMult: True; GBWP_KHz: 10000; IqMax: 1350; LeadContent: Pb-FREE; LeadCount: 5; MAPS Description: 2.7-5.5 10MHz High-Speed Single, OP Amp using 120K Analog EPROM process; MPC: A7CD1YC7XA00; PackingMedia: T/R; Populated: Yes; Stage: REL; tblDeviceFamilyID: 267; TempRange: -40C to +125C; VDDmax: 5.5; VDDmin: 2.5; VosMax: 250; Category: MCHP-Analog; Component Kind: Standard; ComponentLink1Description: Datasheet; ComponentLink1URL: http://www.microchip.com/stellent/idcplg?IdcService=SS_GET_PAGE&nodeId=1335&dDocName=en010438; IntroductionDate: 2006-03-28; Library Name: MCHP-CDB.DbLib; MCHP-ID: MIC0507; MinOrderQty: 5; PackageType: SOT-23; Quantity Override: 1; RailIn: True; RailOut: True; Revision: 1; StableGainMin: 1; Status: Production; Supplier 1: Microchip; Supplier Part Number 1: MCP6021T-E/OT; Type: OpAmp
U9 = IC1347: Low Voltage Precision  Adjustable Shunt Regulator; Manufacturer 1: ON Semiconductor; Manufacturer Part Number 1: TLV431BSN1T1G; Database Table Name: IC; Description_: IC POWER TLV431BSN1T1G Shunt Voltage Reference  SOT23-3; Dev Tools Status: Design; Owner: Narasimha Murthy; Populated: DNP; Category: IC; Component Kind: Standard; ComponentLink1Description: SVN Datasheet; ComponentLink1URL: https://chn-vm-apps.microchip.com/mchprepo/parts/Datasheets/TLV431A-D.PDF; ComponentLink2Description: Local Datasheet; ComponentLink3Description: Open Symbol; ComponentLink4Description: Open Footprint; ComponentLink5Description: Open Footprint 2; ComponentLink6Description: Open Footprint 3; ComponentLink7Description: Open Footprint 4; Library Name: MCHP-CDB.DbLib; MCHP-ID: IC1347; Operating Temperature: -40C to +85C; Package: SOT-23-3; Quantity Override: 1; Revision: 0; Status: MCL Design; Supplier 1: Digi-Key; Supplier Part Number 1: TLV431BSN1T1GOSCT-ND; Symbol: TLV431 SOT-23-3; Type: Power Management; ComponentLink2URL: C:\ALTIUM_WORK\00001_MCHP-CDB\Datasheets\TLV431A-D.PDF; ComponentLink3URL: C:\ALTIUM_WORK\00001_MCHP-CDB\Library\LibraryRefs\TLV431 SOT-23-3.SchLib; ComponentLink4URL: C:\ALTIUM_WORK\00001_MCHP-CDB\Library\Footprints\SOT-23-3.PcbLib
